# Altium schematic sheet Picocopter.SchDoc(Picocopter)
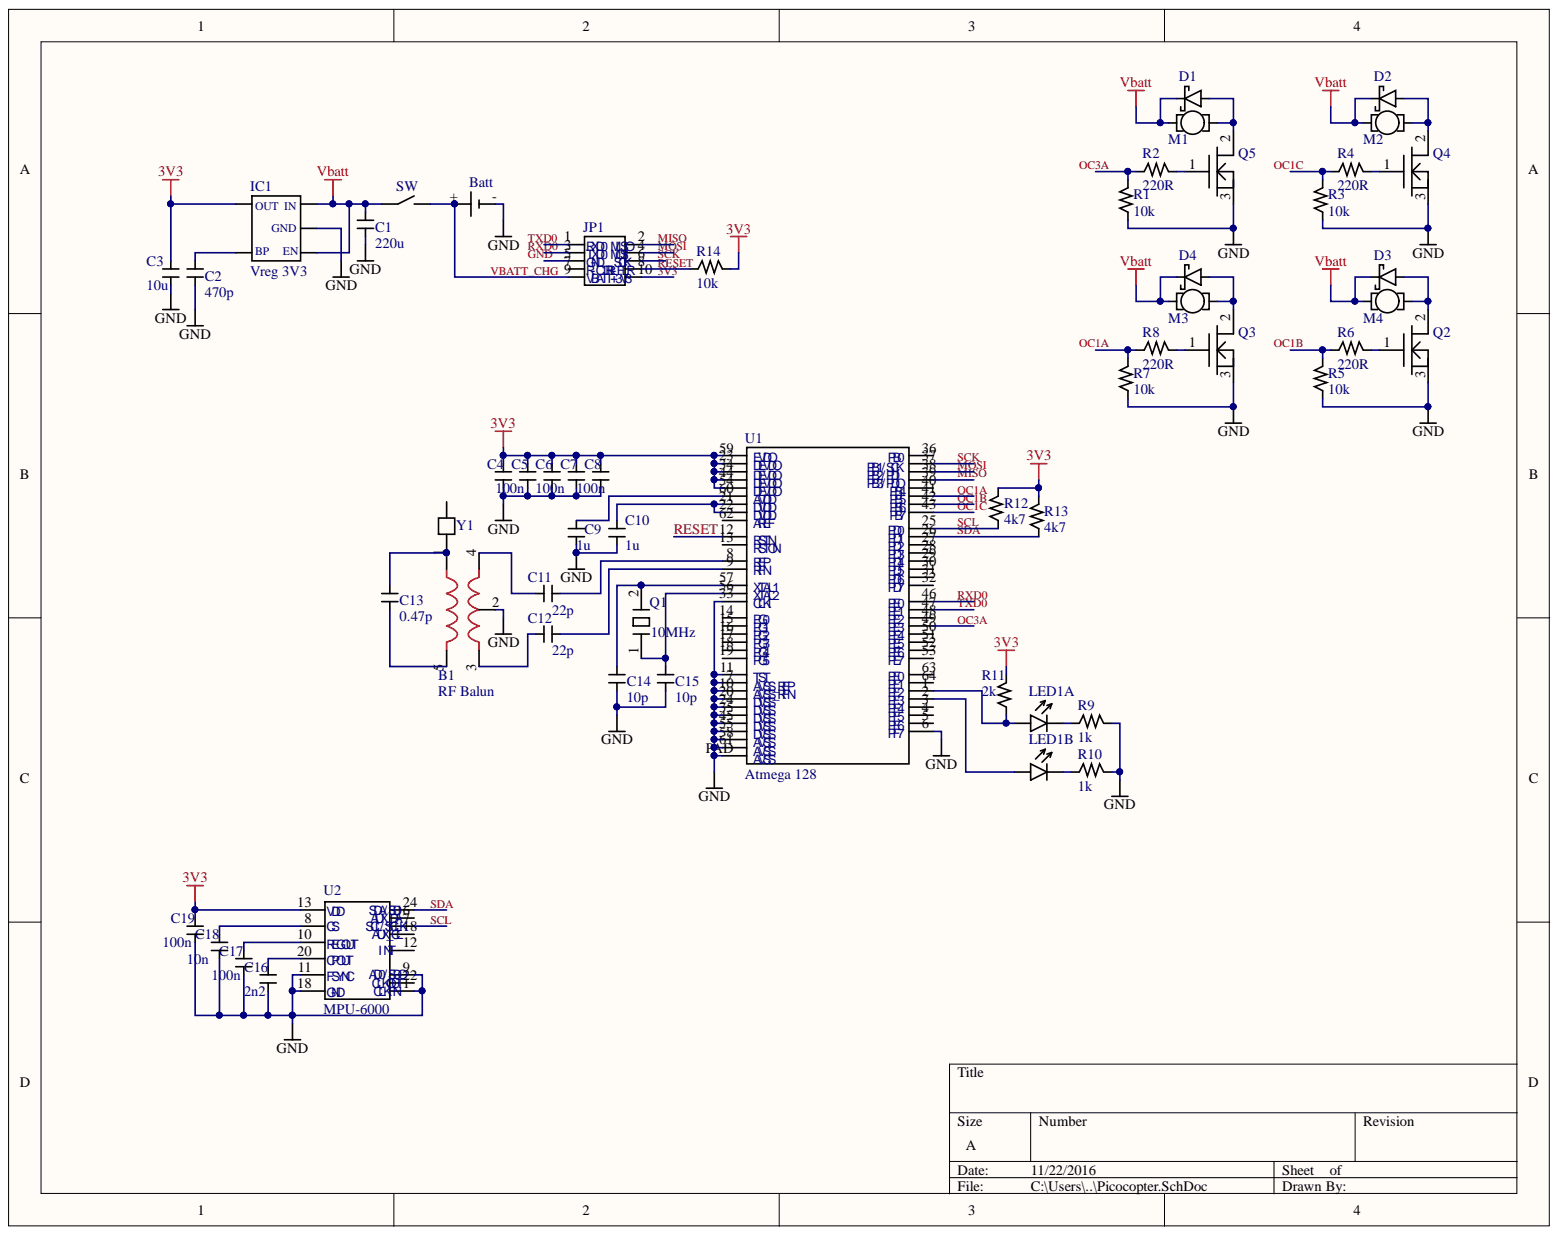
[diagram p0: Picocopter.SchDoc]

## Parts
B1  RF Balun  [2.45 GHz RF Balun]
Batt  Battery  [Battery]  (value not drawn)
C1  220u  [1206 Capacitor]
C2  470p  [0201 Capacitor]
C3  10u  [0402 Capacitor]
C4  100n  [0603 Capacitor]
C5  0603 Capacitor  [0603 Capacitor]  (value not drawn)
C6  100n  [0603 Capacitor]
C7  0603 Capacitor  [0603 Capacitor]  (value not drawn)
C8  100n  [0603 Capacitor]
C9  1u  [0603 Capacitor]
C10  1u  [0603 Capacitor]
C11  22p  [0603 Capacitor]
C12  22p  [0603 Capacitor]
C13  0.47p  [0603 Capacitor]
C14  10p  [0201 Capacitor]
C15  10p  [0201 Capacitor]
C16  2n2  [0603 Capacitor]
C17  100n  [0603 Capacitor]
C18  10n  [0603 Capacitor]
C19  100n  [0603 Capacitor]
D1  Schottky Diode  [Schottky Diode]  (value not drawn)
D2  Schottky Diode  [Schottky Diode]  (value not drawn)
D3  Schottky Diode  [Schottky Diode]  (value not drawn)
D4  Schottky Diode  [Schottky Diode]  (value not drawn)
IC1  Vreg 3V3  [Voltage Regulator]
JP1  10 Pin Connector  [10 Pin Connector]  (value not drawn)
LED1A  PicoLED  [DFN70P60X45-2N]  (value not drawn)
LED1B  PicoLED  [DFN70P60X45-2N]  (value not drawn)
M1  Motor  [Battery]  (value not drawn)
M2  Motor  [Battery]  (value not drawn)
M3  Motor  [Battery]  (value not drawn)
M4  Motor  [Battery]  (value not drawn)
Q1  10MHz  [DFN380P500X110-4N]
Q2  N-Channel MOSFET  [N-Channel MOSFET]  (value not drawn)
Q3  N-Channel MOSFET  [N-Channel MOSFET]  (value not drawn)
Q4  N-Channel MOSFET  [N-Channel MOSFET]  (value not drawn)
Q5  MOSFET  [N-Channel MOSFET]  (value not drawn)
R1  10k  [0402 Resistor]
R2  220R  [0402 Resistor]
R3  10k  [0402 Resistor]
R4  220R  [0402 Resistor]
R5  10k  [0402 Resistor]
R6  220R  [0402 Resistor]
R7  10k  [0402 Resistor]
R8  220R  [0402 Resistor]
R9  1k  [0402 Resistor]
R10  1k  [0402 Resistor]
R11  2k  [0402 Resistor]
R12  4k7  [0402 Resistor]
R13  4k7  [0402 Resistor]
R14  10k  [0402 Resistor]
SW  2 Pin Header  [2 Pin Header]  (value not drawn)
U1  Atmega 128  [Atmega 128]
U2  MPU-6000  [Accelerometer]
Y1  2.4GHz Bluetooth  [2.4GHz Bluetooth]  (value not drawn)

## Nets
VBATT: C1.2 D1.2 D2.2 D3.2 D4.2 IC1.3(IN) IC1.5(EN) M1.3 M2.3 M3.3 M4.3 SW.5
3V3: C3.2 C4.2 C5.2 C6.2 C7.2 C8.2 C19.2 IC1.1(OUT) JP1.10 R11.2 R12.2 R13.2 R14.2 U1.23(EVDO) U1.34(DEVDO) U1.44(DEVDO) U1.54(DEVDO) U1.59 U2.13(VDD)
GND: B1.2 Batt.- C1.1 C2.1 C3.1 C4.1 C5.1 C6.1 C7.1 C8.1 C9.1 C10.1 C14.1 C15.1 C16.1 C17.1 C18.1 C19.1 IC1.4(GND) Q2.3 Q3.3 Q4.3 Q5.3 R1.1 R3.1 R5.1 R7.1 R9.2 R10.2 U1.6(PF6) U1.7 U1.10(AVSS_RFP) U1.11(TST) U1.20(AVSS_RFN) U1.24(DVSS) U1.33(XTAL2) U1.35(DVSS) U1.45(DVSS) U1.55(DVSS) U1.58(DVSS) U1.61(AVSS) U1.PAD(AVSS) U2.1 U2.9 U2.11(FSYNC) U2.18
MISO: JP1.2 U1.39(PB3/PDO)
MOSI: JP1.4 U1.38(PB1/SCK)
OC1A: U1.41
OC1B: U1.42(PB5)
OC1C: U1.43(PB6)
OC3A: U1.49(PE2)
RESET: JP1.8(L) R14.1 U1.12(RSTN)
RXD0: U1.46(PE0)
SCK: JP1.6 U1.37
SCL: R12.1 U1.25(PD0) U2.7
SDA: R13.1 U1.26 U2.24
TXD0: U1.47
VBATT_CHG: Batt.+ JP1.9(R-CTR) SW.6
NetB1_1: B1.1 C13.2 Y1.1
NetB1_3: B1.3 C12.1
NetB1_4: B1.4 C11.1
NetB1_5: B1.5 C13.1
NetC2_2: C2.2 IC1.2(BP)
NetC9_2: C9.2 U1.60(DEVDO)
NetC10_2: C10.2 U1.21(AVDD) U1.22(DVDD)
NetC11_2: C11.2 U1.8(RFP)
NetC12_2: C12.2 U1.9
NetC14_2: C14.2 Q1.2 U1.57
NetC15_2: C15.2 Q1.1 U1.56(XTAL1)
NetC16_2: C16.2 U2.20(CPOUT)
NetC17_2: C17.2 U2.10(REGOUT)
NetC18_2: C18.2 U2.8(CS)
NetD1_1: D1.1 M1.4 Q5.2
NetD2_1: D2.1 M2.4 Q4.2
NetD3_1: D3.1 M4.4 Q2.2
NetD4_1: D4.1 M3.4 Q3.2
NetLED1A_1: LED1A.1 R11.1 U1.1(PF1)
NetLED1A_2: LED1A.2 R9.1
NetLED1B_1: LED1B.1 U1.2(PF2)
NetLED1B_2: LED1B.2 R10.1
NetQ2_1: Q2.1 R6.2
NetQ3_1: Q3.1 R8.2
NetQ4_1: Q4.1 R4.2
NetQ5_1: Q5.1 R2.2
NetR1_2: R1.2 R2.1
NetR3_2: R3.2 R4.1
NetR5_2: R5.2 R6.1
NetR7_2: R7.2 R8.1

Not connected: JP1 1 3 5(TXD0) 7(GND); U1 3(PF3) 4(PF4) 5(PF5) 13 14(PG0) 15 16(PG1) 17(PG2) 18(PG3) 19(PG4) 27(PD1) 28(PD2) 29(PD3) 30(PD4) 31(PD5) 32(PD6) 36(PB0) 40(PB4) 48(PE1) 50(PE3) 51(PE4) 52(PE5) 53(PE6) 62(DVDD) 63(PF0) 64; U2 6 12 22; Y1 2

## Library data of the parts
B1 = 2.45 GHz RF Balun: Component Kind: Standard; Library Name: 2.45 GHz RF Balun.SchLib
C1 = 1206 Capacitor: Component Kind: Standard; Library Name: 1206 Capacitor.SchLib
C2 C14 C15 = 10 pF Capacitor: Component Kind: Standard; Library Name: 0201 Capacitor.SchLib
C3 = 0402 Capacitor: Component Kind: Standard; Library Name: 0402 Capacitor.SchLib
C4 C6 C8-C13 C16-C19 = 0603 Capacitor: Component Kind: Standard; Library Name: 0603 Capacitor.SchLib
IC1 = Voltage Regulator: Component Kind: Standard; Library Name: Voltage Regulator.SchLib
Q1 = 16 MHz Crystal: Component Kind: Standard; Library Name: 16 MHz Crystal.SchLib
Q5 = N-Channel MOSFET: Component Kind: Standard; Library Name: N-Channel MOSFET.SchLib
R1-R14 = 0402 Resistor: Component Kind: Standard; Library Name: 0402 Resistor.SchLib
U2 = Accelerometer: Component Kind: Standard; Library Name: Accelerometer.SchLib
Batt = Battery: Component Kind: Standard; Library Name: Battery.SchLib
C5 C7 = 0603 Capacitor: Component Kind: Standard; Library Name: 0603 Capacitor.SchLib
D1-D4 = Schottky Diode: Component Kind: Standard; Library Name: Schottky Diode.SchLib
JP1 = 10 Pin Connector: Component Kind: Standard; Library Name: 10 Pin Connector.SchLib
LED1A LED1B = PicoLED: Component Kind: Standard; Library Name: PicoLED.SchLib
M1-M4 = Motor: Component Kind: Standard; Library Name: Motor.SchLib
Q2-Q4 = N-Channel MOSFET: Component Kind: Standard; Library Name: N-Channel MOSFET.SchLib
SW = 2 Pin Header: Component Kind: Standard; Library Name: 2 Pin Header.SchLib
U1 = Atmega 128: Component Kind: Standard; Library Name: Atmega 128.SchLib
Y1 = 2.4GHz Bluetooth: Component Kind: Standard; Library Name: 2.4GHz Bluetooth.SchLib
